# Altium schematic sheet Э3("All Documents",Physical)
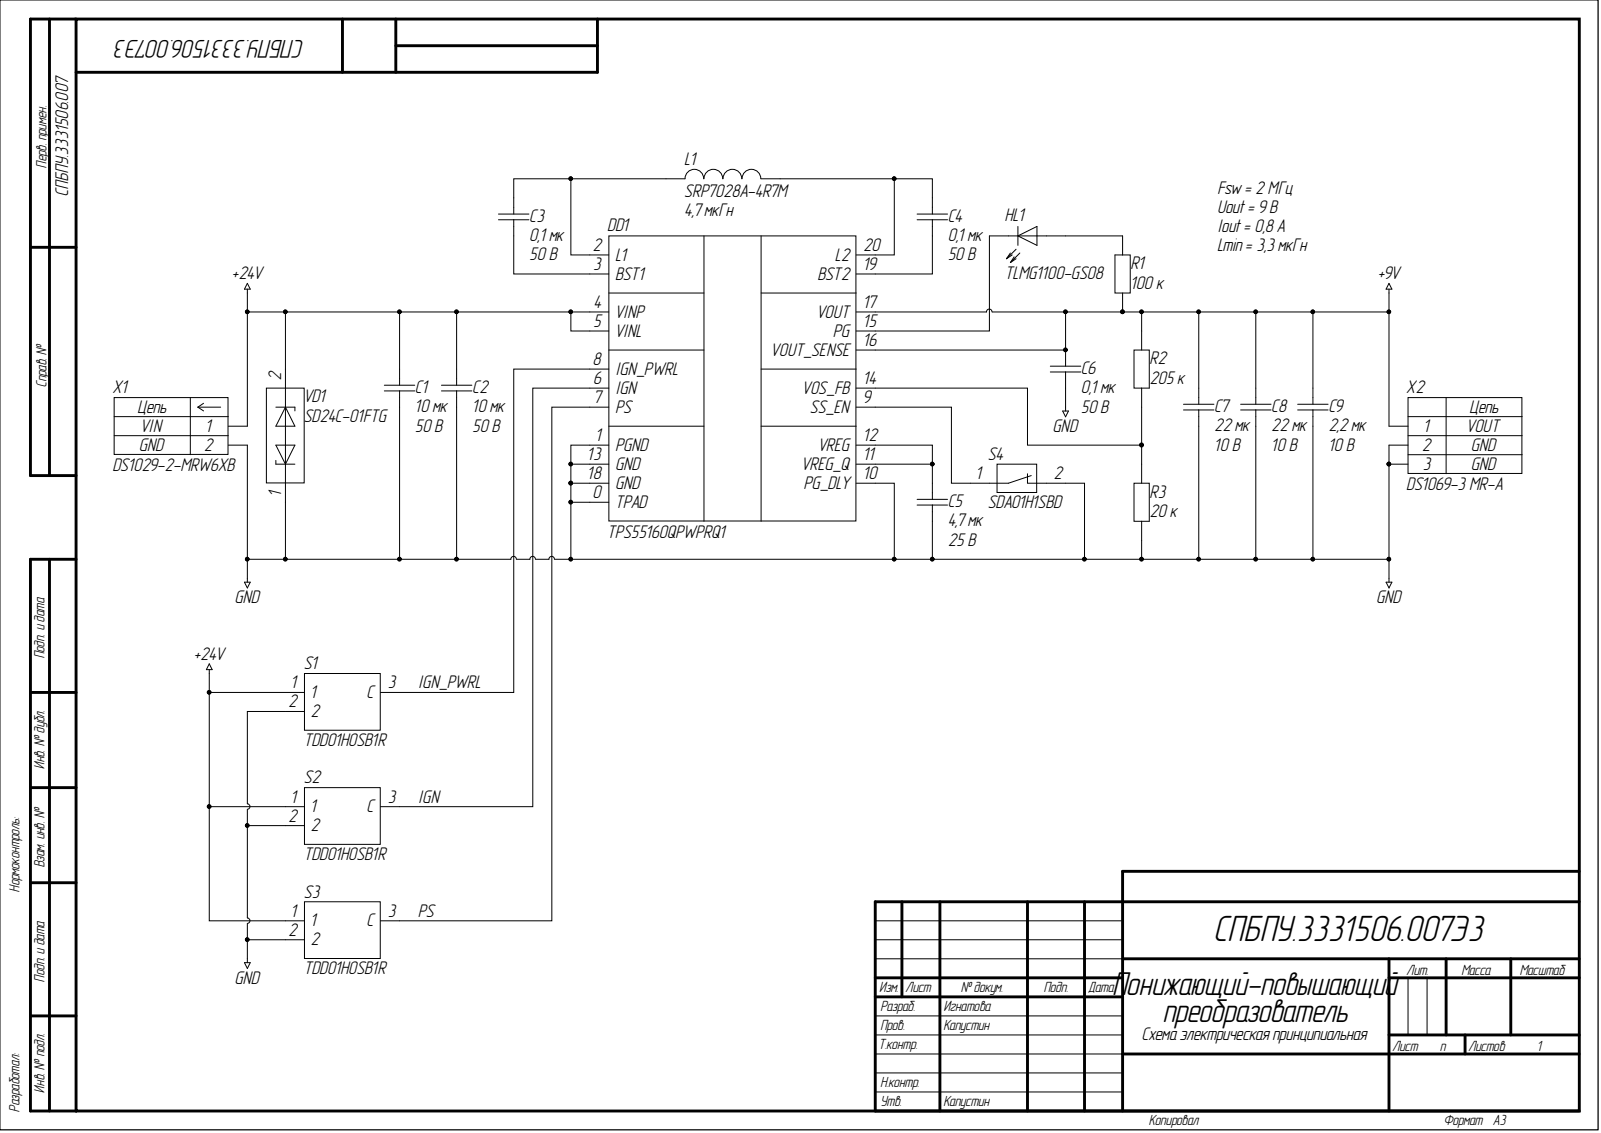
[diagram p0: Э3("All Documents",Physical)]

## Parts
C1  10 мк  [C1206]
C2  10 мк  Номинальное напряжение: 50 В  [C1206]
C3  0,1 мк  Номинальное напряжение: 50 В  [C0603]
C4  0,1 мк  [C0603]
C5  4,7 мк  Номинальное напряжение: 25 В  [C0603]
C6  0,1 мк  Номинальное напряжение: 50 В  [C0603]
C7  22 мк  [C0603]
C8  22 мк  Номинальное напряжение: 10 В  [C0603]
C9  2,2 мк  Номинальное напряжение: 10 В  [C0603]
DD1  TPS55160QPWPRQ1  [HTSSOP-20]
HL1  TLMG1100-GS08  [HL0603]
L1  SRP7028A-4R7M  [SRP-2-730X660X280]
R1  100 к  [R0603]
R2  205 к  [R0603]
R3  20 к  [R0603]
S1  TDD01H0SB1R  [DIP-SWITCH-3-600X420X230]
S2  TDD01H0SB1R  [DIP-SWITCH-3-600X420X230]
S3  TDD01H0SB1R  [DIP-SWITCH-3-600X420X230]
S4  SDA01H1SBD  [DIP-SWITCH-2-452X930X545]
VD1  SD24C-01FTG  [SOD323]
X1  DS1029-2-MRW6XB  Contact_2: GND  Contact_1: VIN  [DS1029-2-MRW6XB]
X2  DS1069-3 MR-A  Contact_2: GND  Contact_1: VOUT  [DS1069-3 CWF-3R]

## Nets
+9V: C6.1 C7.1 C8.1 C9.1 DD1.16(VOUT_SENSE) DD1.17(VOUT) R1.2 R2.2 X2.1
+24V: C1.1 C2.1 DD1.4(VINP) DD1.5(VINL) S1.1 S2.1 S3.1 VD1.2 X1.1
GND: C1.2 C2.2 C5.2 C6.2 C7.2 C8.2 C9.2 DD1.0(TPAD) DD1.1(PGND) DD1.10(PG_DLY) DD1.13(GND) DD1.18(GND) R3.1 S1.2 S2.2 S3.2 S4.2 VD1.1 X1.2 X2.2
IGN: DD1.6(IGN) S2.3
IGN_PWRL: DD1.8(IGN_PWRL) S1.3
PS: DD1.7(PS) S3.3
NetC3_1: C3.1 DD1.2(L1) L1.1
NetC3_2: C3.2 DD1.3(BST1)
NetC4_1: C4.1 DD1.20(L2) L1.2
NetC4_2: C4.2 DD1.19(BST2)
NetC5_1: C5.1 DD1.11(VREG_Q) DD1.12(VREG)
NetDD1_9: DD1.9(SS_EN) S4.1
NetDD1_14: DD1.14(VOS_FB) R2.1 R3.2
NetDD1_15: DD1.15(PG) HL1.1
NetHL1_2: HL1.2 R1.1

## Library data of the parts
C1 = c0043: =Описание; Фамилия: Игнатова; Рабочие температуры: от -55 °С до +85 °С; Производитель: Samsung electro-mechanics; Отклонение: ±10 %; Описание: Конденсатор чип 1206 10 мкФ 50 В ±10 %; Номинальное напряжение: 50 В; Наименование: CL31A106KBHNNNE; Корпус: 1206; Database Table Name: Конденсаторы$; Номинал: 10 мк; Напряжение: 50 В; ID: c0043; Description: Конденсатор чип 1206 10 мкФ 50 В ±10 %; Component Kind: Standard; Library Name: Database_Students.DbLib
C2 = c0043: =Описание; Фамилия: Игнатова; Рабочие температуры: от -55 °С до +85 °С; Производитель: Samsung electro-mechanics; Отклонение: ±10 %; Описание: Конденсатор чип 1206 10 мкФ 50 В ±10 %; Наименование: CL31A106KBHNNNE; Корпус: 1206; Database Table Name: Конденсаторы$; Номинал: 10 мк; Напряжение: 50 В; ID: c0043; Description: Конденсатор чип 1206 10 мкФ 50 В ±10 %; Component Kind: Standard; Library Name: Database_Students.DbLib
C3 C6 = c0014: =Описание; Фамилия: Ларионов; Рабочие температуры: от -55 °С до +125 °С; Производитель: YAGEO Corp.; Отклонение: ±5 %; Описание: Конденсатор чип 0603 0,1 мкФ 50 В ±5 %; Наименование: CC0603JRX7R9BB104; Корпус: 0603; Database Table Name: Конденсаторы$; Номинал: 0,1 мк; Напряжение: 50 В; ID: c0014; HelpURL: https://www.yageo.com/upload/media/product/products/datasheet/mlcc/UPY-GPHC_X7R_6.3V-to-50V_20.pdf; Description: Конденсатор чип 0603 0,1 мкФ 50 В ±5 %; Component Kind: Standard; Library Name: Database_Students.DbLib
C4 = c0014: =Описание; Фамилия: Ларионов; Рабочие температуры: от -55 °С до +125 °С; Производитель: YAGEO Corp.; Отклонение: ±5 %; Описание: Конденсатор чип 0603 0,1 мкФ 50 В ±5 %; Номинальное напряжение: 50 В; Наименование: CC0603JRX7R9BB104; Корпус: 0603; Database Table Name: Конденсаторы$; Номинал: 0,1 мк; Напряжение: 50 В; ID: c0014; HelpURL: https://www.yageo.com/upload/media/product/products/datasheet/mlcc/UPY-GPHC_X7R_6.3V-to-50V_20.pdf; Description: Конденсатор чип 0603 0,1 мкФ 50 В ±5 %; Component Kind: Standard; Library Name: Database_Students.DbLib
C5 = c0029: =Описание; Фамилия: Ларионов; Рабочие температуры: от -55 °С до +85 °С; Производитель: YAGEO Corp.; Отклонение: ±10 %; Описание: Конденсатор чип 0603 4,7 мкФ 25 В ±10 %; Наименование: CC0603KRX5R8BB475; Корпус: 0603; Database Table Name: Конденсаторы$; Номинал: 4,7 мк; Напряжение: 25 В; ID: c0029; HelpURL: https://www.yageo.com/upload/media/product/productsearch/datasheet/mlcc/UPY-GPHC_X5R_4V-to-50V_26.pdf; Description: Конденсатор чип 0603 4,7 мкФ 25 В ±10 %; Component Kind: Standard; Library Name: Database_Students.DbLib
C7 = c0025: =Описание; Фамилия: Чернов; Рабочие температуры: от -55 °С до +85 °С; Производитель: YAGEO Corp.; Отклонение: ±20 %; Описание: Конденсатор чип 0603 22 мкФ 10 В ±20 %; Номинальное напряжение: 10 В; Наименование: CC0603MPX5R6BB226; Корпус: 0603; Database Table Name: Конденсаторы$; Номинал: 22 мк; Напряжение: 10 В; ID: c0025; HelpURL: https://www.yageo.com/upload/media/product/productsearch/datasheet/mlcc/UPY-GPHC_X5R_4V-to-50V_26.pdf; Description: Конденсатор чип 0603 22 мкФ 10 В ±20 %; Component Kind: Standard; Library Name: Database_Students.DbLib
C8 = c0025: =Описание; Фамилия: Чернов; Рабочие температуры: от -55 °С до +85 °С; Производитель: YAGEO Corp.; Отклонение: ±20 %; Описание: Конденсатор чип 0603 22 мкФ 10 В ±20 %; Наименование: CC0603MPX5R6BB226; Корпус: 0603; Database Table Name: Конденсаторы$; Номинал: 22 мк; Напряжение: 10 В; ID: c0025; HelpURL: https://www.yageo.com/upload/media/product/productsearch/datasheet/mlcc/UPY-GPHC_X5R_4V-to-50V_26.pdf; Description: Конденсатор чип 0603 22 мкФ 10 В ±20 %; Component Kind: Standard; Library Name: Database_Students.DbLib
C9 = c0025: =Описание; Фамилия: Чернов; Рабочие температуры: от -55 °С до +125 °С; Производитель: YAGEO Corp.; Отклонение: ±10 %; Описание: Конденсатор чип 0603 2,2 мкФ 10 В ±10 %; Наименование: CC0603KRX7R6BB225; Корпус: 0603; Database Table Name: Конденсаторы$; Номинал: 2,2 мк; Напряжение: 10 В; ID: c0025; HelpURL: https://www.yageo.com/upload/media/product/productsearch/datasheet/mlcc/UPY-GPHC_X7R_6.3V-to-50V_20.pdf; Description: Конденсатор чип 0603 2,2 мкФ 10 В ±10 %; Component Kind: Standard; Library Name: Database_Students.DbLib
DD1 = dd0007: =Описание; Частота переключения: 2 МГц; Фамилия: Игнатова; Рабочие температуры: от -40 °С до +125 °С; Производитель: Texas Instruments; Описание: Понижающий-повышающий преобразователь напряжения 36 В и 1А; Коммутируемый ток: от 0,4 А до 1 А; Выходное напряжение: от 5,7 В до 9 В; Входное напряжение: от 2 В до 36 В; Database Table Name: Преобразователи напряжения$; Наименование: TPS55160QPWPRQ1; Корпус: HTSSOP-20; ID: dd0007; Description: Понижающий-повышающий преобразователь напряжения 36 В и 1А; Component Kind: Standard; Library Name: Database_Students.DbLib
HL1 = hl0005: =Описание; Цвет: Green; Фамилия: Ларионов; Рассеиваемая мощность: 90 мВт; Рабочие температуры: от -40 °С до +100 °С; Производитель: Vishay Intertechnology, Inc.; Описание: Светодиод чип 0603 зеленый; Номинальный прямой ток: 30 мА; Номинальное падение напряжения: 2,1 В; Номинальная сила света: 35 мкд; Номинальная длина волны: 570 нм; Корпус: 0603; Database Table Name: Светодиоды$; Наименование: TLMG1100-GS08; ID: hl0005; HelpURL: https://www.vishay.com/leds/list/product-83173/; Description: Светодиод чип 0603 зеленый; Component Kind: Standard; Library Name: Database_Students.DbLib
L1 = l0006: =Описание; Фамилия: Игнатова; Ток насыщения: 10 А; Резонансная частота: 21 МГц; Рабочие температуры: от -55 °С до +150 °С; Рабочее напряжение: не указано; Производитель: Bourns, Inc.; Отклонение: ±20 %; Описание: Индуктивность 4,7 мкГн ±20 %; Номинальный ток (при нагреве на 20 °С/40 °С): 5,5 А; Номинальное активное сопротивление: 37 мОм; Номинал: 4,7 мкГн; Максимальное активное сопротивление: 40 мОм; Корпус: 7,3 x 6,6 x 2,8; Database Table Name: Индуктивности$; Наименование: SRP7028A-4R7M; Индуктивность: 4,7 мкГн; ID: l0006; Description: Индуктивность 4,7 мкГн ±20 %; Component Kind: Standard; Library Name: Database_Students.DbLib
R1 = r0028: =Описание; Фамилия: Водорезов; Рабочие температуры: от -55 °С до +155 °С; Производитель: YAGEO Corp.; Отклонение: ±1 %; Описание: Резистор чип 0603 100 кОм ±1 % 0,1 Вт; Номинальный ток: 1 А; Номинальное напряжение: 75 В; Наименование: RC0603FR-07100KL; Корпус: 0603; Database Table Name: Резисторы$; Номинал: 100 к; ID: r0028; HelpURL: https://www.yageo.com/upload/media/product/productsearch/datasheet/rchip/PYu-RC_Group_51_RoHS_L_11.pdf; Description: Резистор чип 0603 100 кОм ±1 % 0,1 Вт; Component Kind: Standard; Library Name: Database_Students.DbLib
R2 = r0083: =Описание; Фамилия: Игнатова; Рабочие температуры: от -55 °С до +155 °С; Производитель: YAGEO Corp.; Отклонение: ±1 %; Описание: Резистор чип 0603 205 кОм ±1 % 0,1 Вт; Номинальный ток: 1 А; Номинальное напряжение: 75 В; Наименование: RC0603FR-07205KL; Корпус: 0603; Database Table Name: Резисторы$; Номинал: 205 к; ID: r0083; Description: Резистор чип 0603 205 кОм ±1 % 0,1 Вт; Component Kind: Standard; Library Name: Database_Students.DbLib
R3 = r0022: =Описание; Фамилия: Паньков; Рабочие температуры: от -55 °С до +155 °С; Производитель: YAGEO Corp.; Отклонение: ±1 %; Описание: Резистор чип 0603 20 кОм ±1 % 0,1 Вт; Номинальный ток: 1 А; Номинальное напряжение: 75 В; Наименование: RC0603FR-0720KL; Корпус: 0603; Database Table Name: Резисторы$; Номинал: 20 к; ID: r0022; HelpURL: https://www.yageo.com/upload/media/product/productsearch/datasheet/rchip/PYu-RC_Group_51_RoHS_L_11.pdf; Description: Резистор чип 0603 20 кОм ±1 % 0,1 Вт; Component Kind: Standard; Library Name: Database_Students.DbLib
S1-S3 = sw0004: =Описание; Фамилия: Игнатова; Ток нагрузки: 25 мА @ 24 В; Сопротивление контакта: 100 мОм; Рабочие температуры: от -30 °С до +85 °С; Производитель: C&K; Описание: 2-х позиционный переключатель; Корпус: 6,00х4,20х2,30; Количество контактов: 3; Database Table Name: Переключатели$; Наименование: TDD01H0SB1R; ID: sw0004; Description: 2-х позиционный переключатель; Component Kind: Standard; Library Name: Database_Students.DbLib
S4 = sw0005: =Описание; Фамилия: Игнатова; Ток нагрузки: 100 мА @ 5 В; Сопротивление контакта: 50 мОм; Рабочие температуры: от -40 °С до +85 °С; Производитель: C&K; Описание: движковый переключатель; Корпус: 4,52х9,30х5,45; Количество контактов: 2; Database Table Name: Переключатели$; Наименование: SDA01H1SBD; ID: sw0005; Description: движковый переключатель; Component Kind: Standard; Library Name: Database_Students.DbLib
VD1 = vd0009: =Описание; Фамилия: Игнатова; Производитель: Littelfuse, Inc.; Описание: Двунаправленная диодная сборка для подавления помех, 24 В; Database Table Name: Диодные сборки$; Наименование: SD24C-01FTG; Корпус: SOD323; ID: vd0009; Description: Двунаправленная диодная сборка для подавления помех, 24 В; Component Kind: Standard; Library Name: Database_Students.DbLib
X1 = x0006: =Описание; Фамилия: Ларионов; Производитель: Connfly Electronic Co. LTD.; Описание: CWF 2 pin Type B 2,5 мм вилка угловой; Database Table Name: Разъемы$; Наименование: DS1029-2-MRW6XB; ID: x0006; HelpURL: http://www.connfly.com/productshow.aspx?id=352; Description: CWF 2 pin Type B 2,5 мм вилка угловой; Component Kind: Standard; Library Name: Database_Students.DbLib
X2 = x0008: =Описание; Фамилия: Самарин; Производитель: Connfly Electronic Co. LTD.; Описание: CWF 3 pin Type F 2,5 мм вилка угловой; Database Table Name: Разъемы$; Наименование: DS1069-3 MR-A; ID: x0008; Description: CWF 3 pin Type F 2,5 мм вилка угловой; Component Kind: Standard; Library Name: Database_Students.DbLib
